# Altium schematic sheet Power.SchDoc
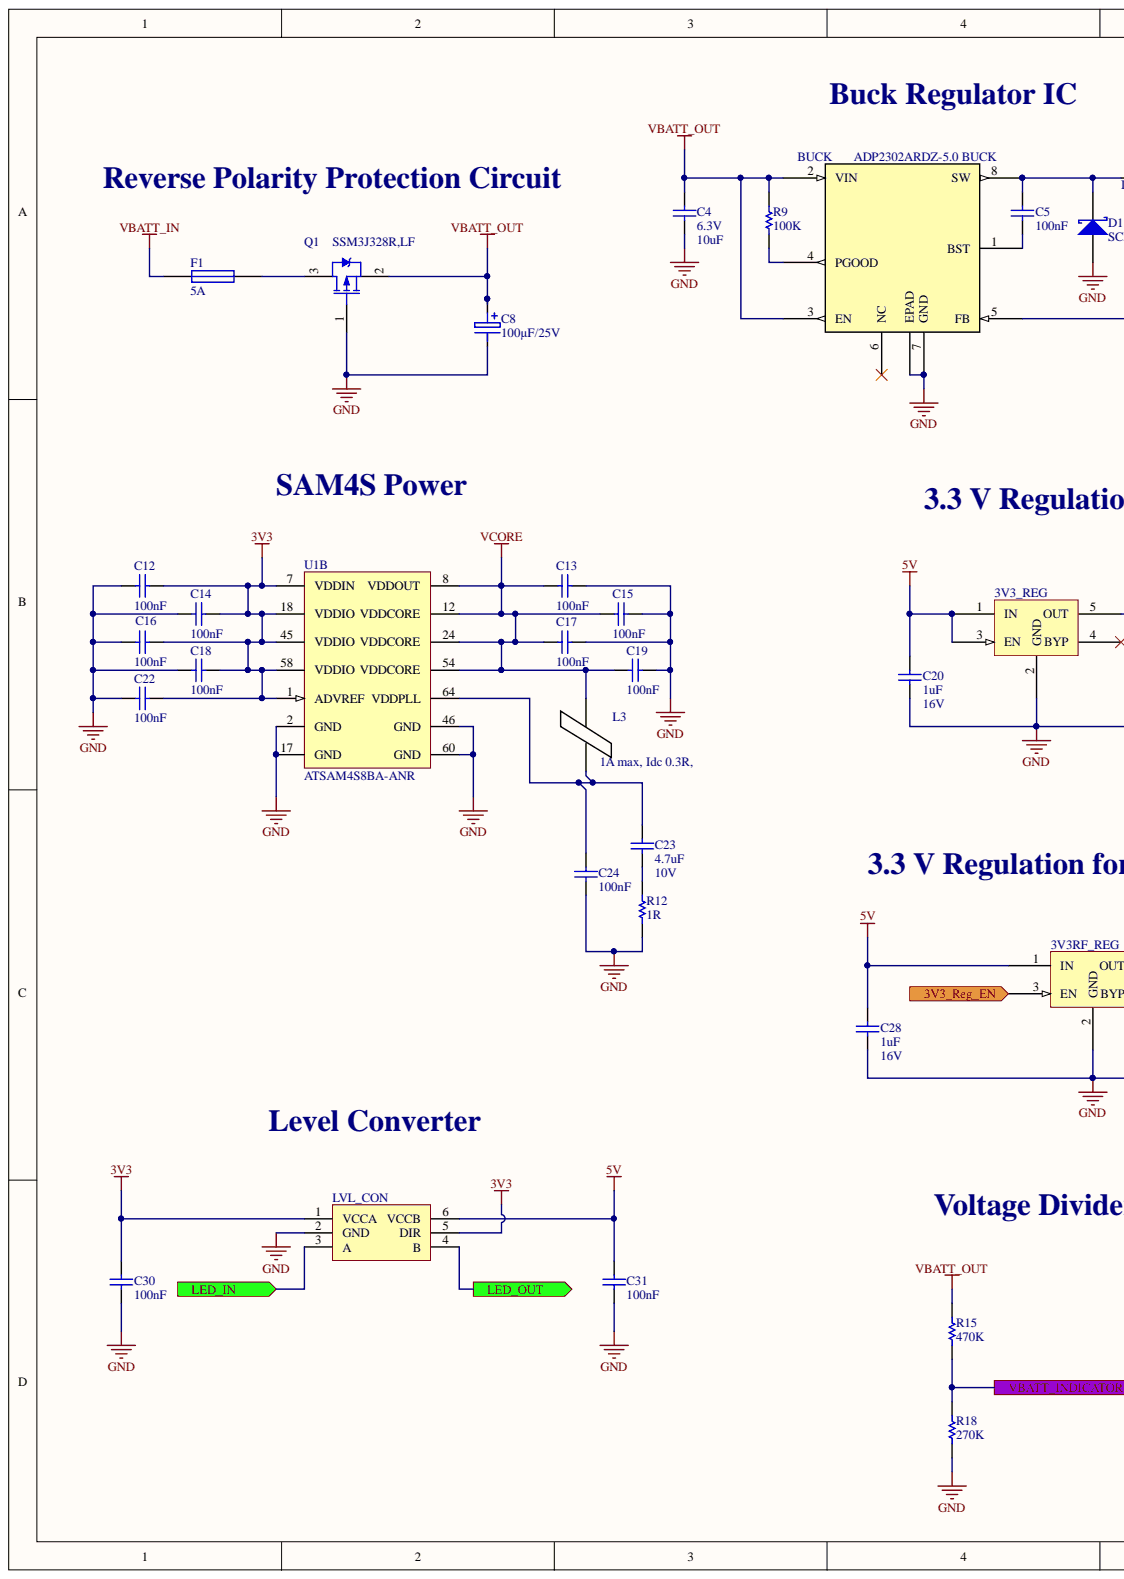
[diagram p0: Power.SchDoc, left]
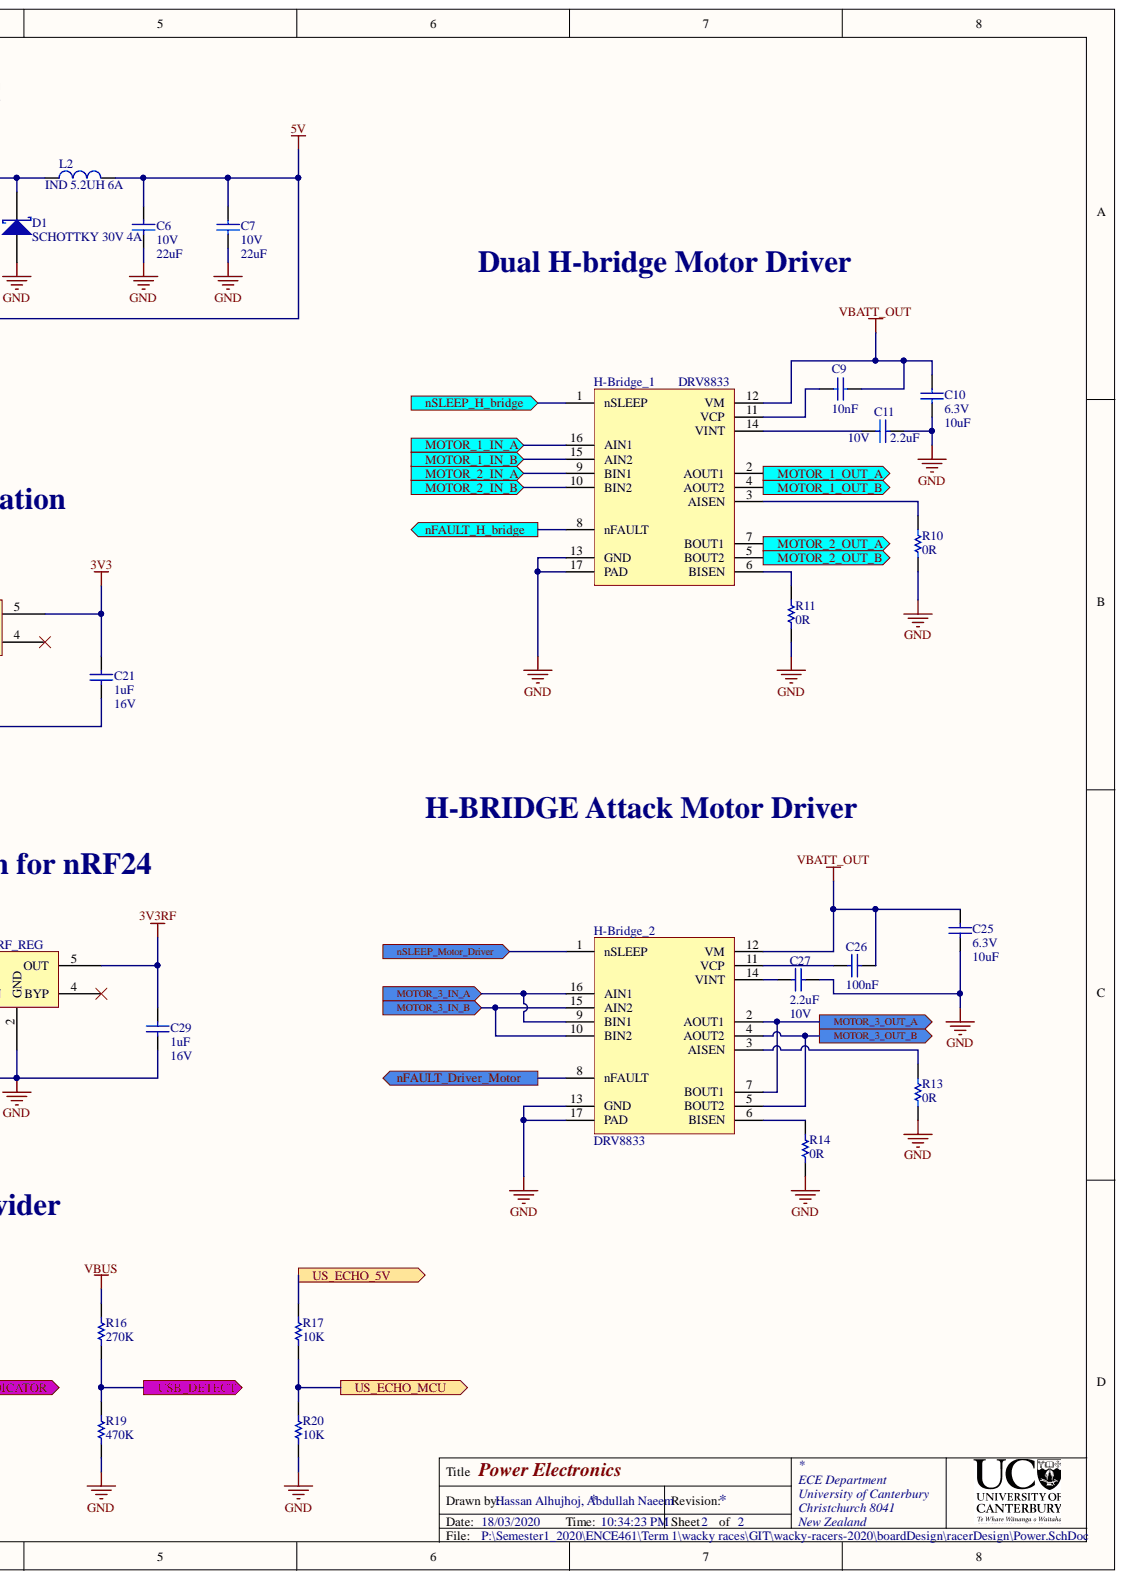
[diagram p1: Power.SchDoc, right]

## Parts
3V3_REG  CAT6219-330TDGT3  [SOT95P280X145-5N]  (value not drawn)
3V3RF_REG  CAT6219-330TDGT3  [SOT95P280X145-5N]  (value not drawn)
BUCK  ADP2302ARDZ-5.0 BUCK  [RD_8_1]
C4  10uF  Voltage - Rated: 6.3V  [CAPC1608L]
C5  100nF  [CAPC1608L]
C6  22uF  Max Voltage: 10V  [1206 (3216 metric)]
C7  22uF  Max Voltage: 10V  [1206 (3216 metric)]
C8  100µF/25V  [CAPAE6666X77M]
C9  10nF  [CAPC1608L]
C10  10uF  Voltage - Rated: 6.3V  [CAPC1608L]
C11  2.2uF  Max Voltage: 10V  [CAPC1608L]
C12  100nF  [CAPC1608L]
C13  100nF  [CAPC1608L]
C14  100nF  [CAPC1608L]
C15  100nF  [CAPC1608L]
C16  100nF  [CAPC1608L]
C17  100nF  [CAPC1608L]
C18  100nF  [CAPC1608L]
C19  100nF  [CAPC1608L]
C20  1uF  Max Voltage: 16V  [CAPC1608L]
C21  1uF  Max Voltage: 16V  [CAPC1608L]
C22  100nF  [CAPC1608L]
C23  4.7uF  Max Voltage: 10V  [CAPC1608N]
C24  100nF  [CAPC1608L]
C25  10uF  Voltage - Rated: 6.3V  [CAPC1608L]
C26  100nF  [CAPC1608L]
C27  2.2uF  Max Voltage: 10V  [CAPC1608L]
C28  1uF  Max Voltage: 16V  [CAPC1608L]
C29  1uF  Max Voltage: 16V  [CAPC1608L]
C30  100nF  [CAPC1608L]
C31  100nF  [CAPC1608L]
D1  SCHOTTKY 30V 4A  [SMC]
F1  5A  [RESC3216N]
H-Bridge_1  DRV8833  [HTSOP16]
H-Bridge_2  DRV8833  [HTSOP16]
L2  IND 5.2UH 6A  [INDUCTOR5u2]
L3  1A max, Idc 0.3R,  [INDC3216L]
LVL_CON  SN74LVC1T45  [SOT23-6_N]  (value not drawn)
Q1  SSM3J328R,LF  [SOT23_L]
R9  100K  [RESC1608L]
R10  0R  [RESC1608L]
R11  0R  [RESC1608L]
R12  1R  [RESC3216L]
R13  0R  [RESC1608L]
R14  0R  [RESC1608L]
R15  470K  [RESC1608L]
R16  270K  [RESC1608L]
R17  10K  [RESC1608L]
R18  270K  [RESC1608L]
R19  470K  [RESC1608L]
R20  10K  [RESC1608L]
U1  ATSAM4S8BA-ANR  [LQFP64_L]  (part B)

## Ports
3V3_Reg_EN, LED_IN, LED_OUT, MOTOR_1_IN_A, MOTOR_1_IN_B, MOTOR_1_OUT_A, MOTOR_1_OUT_B, MOTOR_2_IN_A, MOTOR_2_IN_B, MOTOR_2_OUT_A, MOTOR_2_OUT_B, MOTOR_3_IN_A, MOTOR_3_IN_B, MOTOR_3_OUT_A, MOTOR_3_OUT_B, nFAULT_Driver_Motor, nFAULT_H_bridge, nSLEEP_H_bridge, nSLEEP_Motor_Driver, US_ECHO_5V, US_ECHO_MCU, USB_DETECT, VBATT_INDICATOR

## Nets
3V3: 3V3_REG.5(OUT) C12.2 C14.2 C16.2 C18.2 C21.2 C22.2 C30.2 LVL_CON.1(VCCA) LVL_CON.5(DIR) U1.1(ADVREF) U1.7(VDDIN) U1.18(VDDIO) U1.45(VDDIO) U1.58(VDDIO)
3V3RF: 3V3RF_REG.5(OUT) C29.2
5V: 3V3_REG.1(IN) 3V3_REG.3(EN) 3V3RF_REG.1(IN) BUCK.5(FB) C6.2 C7.2 C20.1 C28.1 C31.2 L2.2 LVL_CON.6(VCCB)
GND: 3V3_REG.2(GND) 3V3RF_REG.2(GND) BUCK.7(GND) BUCK.EPAD C4.1 C6.1 C7.1 C8.1 C10.1 C11.2 C12.1 C13.2 C14.1 C15.2 C16.1 C17.2 C18.1 C19.2 C20.2 C21.1 C22.1 C24.1 C25.1 C27.2 C28.2 C29.1 C30.1 C31.1 D1.1 H-Bridge_1.13(GND) H-Bridge_1.17(PAD) H-Bridge_2.13(GND) H-Bridge_2.17(PAD) LVL_CON.2(GND) Q1.1 R10.2 R11.1 R12.2 R13.2 R14.2 R18.1 R19.1 R20.1 U1.2(GND) U1.17(GND) U1.46(GND) U1.60(GND)
VBATT_IN: F1.1
VBATT_OUT: BUCK.2(VIN) BUCK.3(EN) C4.2 C8.2 C9.2 C10.2 C25.2 C26.2 H-Bridge_1.12(VM) H-Bridge_2.12(VM) Q1.2 R9.2 R15.2
VBUS: R16.2
VCORE: C13.1 C15.1 C17.1 C19.1 L3.2 U1.8(VDDOUT) U1.12(VDDCORE) U1.24(VDDCORE) U1.54(VDDCORE)
3V3_Reg_EN: 3V3RF_REG.3(EN) ; port 3V3_Reg_EN
LED_IN: LVL_CON.3(A) ; port LED_IN
LED_OUT: LVL_CON.4(B) ; port LED_OUT
MOTOR_1_IN_A: H-Bridge_1.16(AIN1) ; port MOTOR_1_IN_A
MOTOR_1_IN_B: H-Bridge_1.15(AIN2) ; port MOTOR_1_IN_B
MOTOR_1_OUT_A: H-Bridge_1.2(AOUT1) ; port MOTOR_1_OUT_A
MOTOR_1_OUT_B: H-Bridge_1.4(AOUT2) ; port MOTOR_1_OUT_B
MOTOR_2_IN_A: H-Bridge_1.9(BIN1) ; port MOTOR_2_IN_A
MOTOR_2_IN_B: H-Bridge_1.10(BIN2) ; port MOTOR_2_IN_B
MOTOR_2_OUT_A: H-Bridge_1.7(BOUT1) ; port MOTOR_2_OUT_A
MOTOR_2_OUT_B: H-Bridge_1.5(BOUT2) ; port MOTOR_2_OUT_B
MOTOR_3_IN_A: H-Bridge_2.9(BIN1) H-Bridge_2.16(AIN1) ; port MOTOR_3_IN_A
MOTOR_3_IN_B: H-Bridge_2.10(BIN2) H-Bridge_2.15(AIN2) ; port MOTOR_3_IN_B
MOTOR_3_OUT_A: H-Bridge_2.2(AOUT1) H-Bridge_2.7(BOUT1) ; port MOTOR_3_OUT_A
MOTOR_3_OUT_B: H-Bridge_2.4(AOUT2) H-Bridge_2.5(BOUT2) ; port MOTOR_3_OUT_B
nFAULT_Driver_Motor: H-Bridge_2.8(nFAULT) ; port nFAULT_Driver_Motor
nFAULT_H_bridge: H-Bridge_1.8(nFAULT) ; port nFAULT_H_bridge
nSLEEP_H_bridge: H-Bridge_1.1(nSLEEP) ; port nSLEEP_H_bridge
nSLEEP_Motor_Driver: H-Bridge_2.1(nSLEEP) ; port nSLEEP_Motor_Driver
US_ECHO_5V: R17.2 ; port US_ECHO_5V
US_ECHO_MCU: R17.1 R20.2 ; port US_ECHO_MCU
USB_DETECT: R16.1 R19.2 ; port USB_DETECT
VBATT_INDICATOR: R15.1 R18.2 ; port VBATT_INDICATOR
NetBUCK_1: BUCK.1(BST) C5.1
NetBUCK_4: BUCK.4(PGOOD) R9.1
NetBUCK_8: BUCK.8(SW) C5.2 D1.2 L2.1
NetC9_1: C9.1 H-Bridge_1.11(VCP)
NetC11_1: C11.1 H-Bridge_1.14(VINT)
NetC23_1: C23.1 R12.1
NetC23_2: C23.2 C24.2 L3.1 U1.64(VDDPLL)
NetC26_1: C26.1 H-Bridge_2.11(VCP)
NetC27_1: C27.1 H-Bridge_2.14(VINT)
NetF1_2: F1.2 Q1.3
NetH-Bridge_1_3: H-Bridge_1.3(AISEN) R10.1
NetH-Bridge_1_6: H-Bridge_1.6(BISEN) R11.2
NetH-Bridge_2_3: H-Bridge_2.3(AISEN) R13.1
NetH-Bridge_2_6: H-Bridge_2.6(BISEN) R14.1

Not connected: 3V3_REG 4(BYP); 3V3RF_REG 4(BYP); BUCK 6(NC)

## Library data of the parts
3V3_REG 3V3RF_REG = SV_CAT6219-330TDGT3: IC REG LIN 3.3V 500MA TSOT23-5; Voltage - Input (Max): 5.5V; Voltage Dropout (Max): 0.5V @ 500mA; Base Part Number: CAT6219; Component Kind: Standard; ComponentLink1Description: http://www.onsemi.com/pub/Collateral/CAT6219-D.PDF; ComponentLink1URL: http://www.onsemi.com/pub/Collateral/CAT6219-D.PDF; Current - Output: 500mA; Library Name: ENCE461AD19_19V2.IntLib; Manufacturer Part Number: CAT6219-330TDGT3; Package / Case: SOT-23-5 Thin, TSOT-23-5; Supplier: Digi-Key; Supplier 1: Digi-Key; Supplier Device Package: TSOT-23-5; Voltage - Output (Min/Fixed): 3.3V
BUCK = ADP2302ARDZ-5.0-R7: IC REG BUCK 5V 2A 8SOIC; Frequency - Switching: 700kHz; Output Type: Fixed; Vendor: Analog Devices Inc; Voltage - Input (Max): 20V; Component Kind: Standard; Current - Output: 2A; Library Name: ENCE461AD19_19V2.IntLib; Manufacturer_Part_Number: ADP2302ARDZ-5.0-R7; Supplier 1: Digi-Key; Supplier Part Number 1: ADP2302ARDZ-5.0-R7CT-ND; Type: DEV; Value: Value; Voltage - Output (Min/Fixed): 5V
C4 C10 C25 = CC_10uF_0603: CAP CER 10UF X5R +-20% 6V3 0603; Component Kind: Standard; Library Name: ECE AD19Components_19V1.IntLib; Signal Integrity: C0805; Simulation: CAP; Storage Location: PCB Assembly WS; Supplier: ECE Dept; Value: 10uF
C5 C12-C19 C22 C24 C26 C30 C31 = CC_100nF_0603: CAP CER 100nF X7R +-10% 50V 0603; Code_IPC: 1608 [0603]; Component Kind: Standard; Library Name: ECE AD19Components_19V1.IntLib; PackageReference: 0603; Signal Integrity: C0603; Simulation: CAP; Storage Location: PCB Assembly WS; Supplier: ECE Dept; Value: 100nF
C6 C7 = CC_22uF_1206: CAP CER 22uF Y5V -20% +80% 10V 1206; Component Kind: Standard; Dielectric: Y5V; Library Name: ECE AD19Components_19V1.IntLib; Simulation: CAP; Storage Location: PCB Assembly WS; Supplier: ECE Dept; Value: 22uF
C8 = CA_100uF_25V: CAP ALUM 100UF 25V 20% SMD; Manufacturer: Nichicon; Manufacturer Part Number: UWT1E101MCL1GS; Ripple Current: 91mA; Capacitance: 100µF/25V; Component Kind: Standard; Height - Seated (Max): 0.303" (7.70mm); Library Name: ECE AD19Components_19V1.IntLib; Mounting Type: Surface Mount; Operating Temperature: -55°C ~ 105°C; Package / Case: Radial, Can - SMD; Size / Dimension: 0.248" Dia (6.30mm); Supplier 1: Digi-Key; Supplier Part Number 1: 493-2190-1-ND
C9 = CC_10nF_0603: CAP CER 10nF X7R +-10% 50V 0603; Code_IPC: 1608 [0603]; Component Kind: Standard; Library Name: ECE AD19Components_19V1.IntLib; PackageReference: 0603; Signal Integrity: C0603; Simulation: CAP; Storage Location: PCB Assembly WS; Supplier: ECE Dept; Value: 10nF
C11 C27 = CC_2.2uF_0603: CAP CER 2.2uF X5R +-20% 10V 0603; Code_IPC: 1608 [0603]; Component Kind: Standard; Library Name: ECE AD19Components_19V1.IntLib; PackageReference: 0603; Signal Integrity: C0603; Simulation: CAP; Storage Location: PCB Assembly WS; Supplier: ECE Dept; Value: 2.2uF
C20 C21 C28 C29 = CC_1uF_0603: CAP CER 1uF Y5V -20% +80% 16V 0603; Code_IPC: 1608 [0603]; Component Kind: Standard; Library Name: ECE AD19Components_19V1.IntLib; PackageReference: 0603; Signal Integrity: C0603; Simulation: CAP; Storage Location: PCB Assembly WS; Supplier: ECE Dept; Value: 1uF
C23 = CC_4.7uF_0603: CAP CER 4.7uF X5R +-20%  10V 0603; Note: Footprint corresponds to dimensions for bulk cassette packaging. This case size has tightened tolerances.; Code_IPC: 2012[0805]; Component Kind: Standard; Library Name: ECE AD19Components_19V1.IntLib; PackageReference: 0805; Simulation: CAP; Storage Location: PCB Assembly WS; Supplier: ECE Dept; Value: 4.7uF
D1 = MBRS330T3G: DIODE SCHOTTKY 30V 4A SMC; Manufacturer: ON Semiconductor; Speed: Fast Recovery =< 500ns, > 200mA (Io); Base Part Number: MBRS330; Capacitance @ Vr, F: -; Category: Discrete Semiconductor Products; Component Kind: Standard; ComponentLink1Description: http://www.onsemi.com/pub/Collateral/MBRS340T3-D.PDF; ComponentLink1URL: http://www.onsemi.com/pub/Collateral/MBRS340T3-D.PDF; Current - Average Rectified (Io): 4A; Diode Type: Schottky; Library Name: ENCE461AD19_19V2.IntLib; Manufacturer Part Number: MBRS330T3G; Mounting Type: Surface Mount; Operating Temperature - Junction: -65°C ~ 150°C; Package / Case: DO-214AB, SMC; Packaging: Cut Tape (CT); Part Status: Active; Pricing 1: 1=0.93, 10=0.79, 100=0.5902, 500=0.46368, 1000=0.35829 (NZD); RoHS: RoHS Compliant; Stock 1: 8919; Supplier 1: Digi-Key; Supplier Device Package: SMC; Supplier Part Number 1: MBRS330T3GOSCT-ND
F1 = FS_Fuse_5.0A: Fuse 5.0A Fast Acting 1206 SMD; Manufacturer: Bel TRP; Manufacturer Part Number: C1F5; Approvals: CE,cURus; DC Cold Resistance: 19mR; Fuse Type: Board Mount (Cartridge Style Excluded); Voltage Rating: 125V; Voltage Rating (DC): 63V; Case/Package: 1206; Case Code (Imperial): 1206; Case Code (Metric): 3216; Component Kind: Standard; ComponentLink1Description: http://www.farnell.com/datasheets/2571625.pdf; ComponentLink1URL: http://www.farnell.com/datasheets/2571625.pdf; ComponentLink2Description: http://belfuse.com/pdfs/C1F.pdf; ComponentLink2URL: http://belfuse.com/pdfs/C1F.pdf; ComponentLink3Description: http://www.mouser.com/ds/2/643/C1F-594910.pdf; ComponentLink3URL: http://www.mouser.com/ds/2/643/C1F-594910.pdf; ComponentLink4Description: https://belfuse.com/resources/StewartConnector/C1F.pdf; ComponentLink4URL: https://belfuse.com/resources/StewartConnector/C1F.pdf; Contact Plating: Nickel,Tin; Current Rating: 5A; Length: 0.126inch; Library Name: ECE AD19Components_19V1.IntLib; Max Operating Temperature: 125°C; Min Operating Temperature: -55°C; Mount: Surface Mount; Number of Pins: 2; Packaging: Tape and Reel; Pricing 1: 1=0.32, 5=0.312, 10=0.298, 50=0.2662, 100=0.2479, 250=0.22032, 500=0.1836, 1000=0.17442 (USD); Stock 1: 725; Supplier 1: Digi-Key; Supplier 2: Element14; Supplier Part Number 1: 507-1886-1-ND; Supplier Part Number 2: 2848595; Value: 5A; Voltage Rating (AC): 125V; Width: 0.062inch
H-Bridge_1 H-Bridge_2 = DRV8833: Dual H-Bridge Motor Driver 1.5A; Component Kind: Standard; Library Name: ENCE461AD19_19V2.IntLib
L2 = CDRH104RNP-5R2NC: FIXED IND 5.2UH 6A 22 MOHM SMD; Manufacturer: Sumida America Components Inc.; Current - Saturation: 5.6A; DC Resistance (DCR): 22 mOhm Max; Material - Core: Ferrite; Shielding: Shielded; Tolerance: ±30%; Category: Inductors, Coils, Chokes; Component Kind: Standard; ComponentLink1Description: http://products.sumida.com/products/pdf/CDRH104R.pdf; ComponentLink1URL: http://products.sumida.com/products/pdf/CDRH104R.pdf; Frequency - Self Resonant: -; Height - Seated (Max): 0.157" (4.00mm); Library Name: ENCE461AD19_19V2.IntLib; Manufacturer Part Number: CDRH104RNP-5R2NC; Mounting Type: Surface Mount; Operating Temperature: -40°C ~ 100°C; Package / Case: Nonstandard; Packaging: Cut Tape (CT); Part Status: Active; Pricing 1: 1=2.9, 10=2.678, 100=1.8743, 500=1.5173 (NZD); Q @ Freq: -; Ratings: -; RoHS: RoHS Compliant; Size / Dimension: 0.402" L x 0.394" W (10.20mm x 10.00mm); Stock 1: 3849; Supplier 1: Digi-Key; Supplier Device Package: -; Supplier Part Number 1: 308-2280-1-ND; Type: -
L3 = IN_Ferrite-Bead: Ferrite Bead, Rdc 0.3R, Z100MHZ 600R, Imax 1A, SMD 1206; Manufacturer: FAIR-RITE; Manufacturer Part Number: 2512066017Y1; DC Current Rating: 1; DC Resistance Max: 0.08; Ferrite Case Style: 1206 ^3216 Metric]; Frequency Range: 50MHz to 1; Impedance: 600; Impedance Tolerance: ± 25%; Component Kind: Standard; Ferrite Mounting: SMD; Library Name: ECE AD19Components_19V1.IntLib; Manufacturer PN: 2512066017Y1; Signal Integrity: Inductor Isolated; Storage Location: PCB Assembly WS; Supplier: ECE Dept; Supplier 1: Farnell; Supplier Part Number 1: 1612060; Value: 1A max, Idc 0.3R,
LVL_CON = SN74LVC1T45: 1.8V - 5V level converter; Component Kind: Standard; ComponentLink1Description: Single-Bit Dual-Supply Bus Transceiver with Configurable Voltage-Level Shifting and 3-State Outputs; ComponentLink1URL: http://www.ti.com/lit/ds/symlink/sn74lvc1t45.pdf; Library Name: ENCE461_extras_2020.IntLib
Q1 = TM_SSM3J328R: MOSFET P-CH 20V 6A SOT23-3; Manufacturer: Toshiba; Drain to Source Breakdown Voltage: -20V; Drain to Source Resistance: 47.4mR; Element Configuration: Single; FET Feature: Logic Level Gate, 1.5V Drive; FET Type: MOSFET P-Channel, Metal Oxide; Gate Charge (Qg) @ Vgs: 12.8nC @ 4.5V; Gate to Source Voltage (Vgs): 8V; Input Capacitance: 840pF; Input Capacitance (Ciss) @ Vds: 840pF @ 10V; Max Power Dissipation: 1W; Number of Channels: 1; Power Dissipation: 2W; Rds On Max: 29.8mR; Turn-Off Delay Time: 107ns; Turn-On Delay Time: 32ns; Vgs(th) (Max) @ Id: 1V @ 1mA; Case/Package: SOT-23-3; Component Kind: Standard; ComponentLink1Description: https://datasheet.ciiva.com/11158/ssm3j328r-datasheet-en-20140301-11158652.pdf; ComponentLink1URL: https://datasheet.ciiva.com/11158/ssm3j328r-datasheet-en-20140301-11158652.pdf; Continuous Drain Current (ID): 6A; Current - Continuous Drain (Id) @ 25° C: 6A; Drain to Source Voltage (Vdss): 20V; Library Name: ECE AD19Components_19V1.IntLib; Manufacturer Part Number: SSM3J328R,LF; Max Operating Temperature: 150°C; Min Operating Temperature: -55°C; Mount: Surface Mount; Number of Elements: 1; Number of Pins: 3; Package / Case: SOT-23-3 Flat Leads; Package Quantity: 3000; Packaging: Tape and Reel; Power - Max: 1W; Pricing: 1=0.79, 10=0.658, 25=0.5772, 100=0.4939, 250=0.42836, 500=0.36284, 1000=0.27972 (NZD); Radiation Hardening: No; Storage Location: PCB Assembly WS; Supplier: Digi-Key; Supplier 1: Digi-Key; Supplier Device Package: SOT-23F; Supplier Part Number: SSM3J328RLFDKR-ND; Supplier Part Number 1: SSM3J328RLFDKR-ND
R9 = RS_100K: RES 100K OHM 1/10W 5% 0603 SMD; Component Kind: Standard; Library Name: ECE AD19Components_19V1.IntLib; Signal Integrity: Res; Simulation: RESISTOR; Storage Location: PCB Assembly WS; Supplier: ECE Dept; Value: 100K
R10 R11 R13 R14 = RS_0R0: RES 0.0 OHM 1/10W 5% 0603 SMD; Component Kind: Standard; Library Name: ECE AD19Components_19V1.IntLib; Signal Integrity: Res; Simulation: RESISTOR; Storage Location: PCB Assembly WS; Supplier: ECE Dept; Value: 0R
R12 = RT_1R: RES 1R OHM 1/4W 5% 1206 SMD; Component Kind: Standard; Library Name: ECE AD19Components_19V1.IntLib; Storage Location: PCB Assembly WS; Supplier: ECE Dept; Value: 1R
R15 R16 = RS_27R: RES 27 OHM 1/10W 5% 0603 SMD; Component Kind: Standard; Library Name: ECE AD19Components_19V1.IntLib; Signal Integrity: Res; Simulation: RESISTOR; Storage Location: PCB Assembly WS; Supplier: ECE Dept
  R15: Value: 470K
  R16: Value: 270K
R17 R20 = RS_10K: RES 10.0K OHM 1/10W 5% 0603 SMD; Component Kind: Standard; Library Name: ECE AD19Components_19V1.IntLib; Signal Integrity: Res; Simulation: RESISTOR; Storage Location: PCB Assembly WS; Supplier: ECE Dept; Value: 10K
R18 R19 = RS_47R: RES 47 OHM 1/10W 5% 0603 SMD; Component Kind: Standard; Library Name: ECE AD19Components_19V1.IntLib; Signal Integrity: Res; Simulation: RESISTOR; Storage Location: PCB Assembly WS; Supplier: ECE Dept
  R18: Value: 270K
  R19: Value: 470K
U1 = SE_ATSAM4S16BA-MU: IC MCU 32BIT 512KB FLASH 64LQFP; Manufacturer: Atmel; Connectivity: I²C, IrDA, Memory Card, SPI, SSC, UART/USART, USB; Core Processor: ARM® Cortex®-M4; Core Size: 32-Bit; Data Converters: A/D 11x12b, D/A 2x12b; Number of I/O: 47; Peripherals: Brown-out Detect/Reset, DMA, POR, PWM, WDT; Program Memory Size: 512KB (512K x 8); RAM Size: 128K x 8; Speed: 120MHz; Voltage - Supply (Vcc/Vdd): 1.62 V ~ 3.6 V; Code_JEDEC: MO-220VMMD-3; Component Kind: Standard; ComponentLink1Description: Manufacturer URL; ComponentLink1URL: http://www.atmel.com/; ComponentLink2Description: SAM4S Series Datasheet; ComponentLink2URL: http://www.atmel.com/dyn/resources/prod_documents/11100.pdf; ComponentLink3Description: SAM4S Series Summary; ComponentLink3URL: http://www.atmel.com/dyn/resources/prod_documents/11100S.pdf; Library Name: ECE AD19Components_19V1.IntLib; Manufacturer Part Number: ATSAM4S8BA-ANR; Package / Case: 64-LQFP; PackageDescription: 64-Pin Quad Flat No-Lead (QFN) Sawn, 9 x 9 x 1.0 mm Body, 0.5 mm Pitch, Exposed Pad; PackageReference: 64PS; PackageVersion: revJ, Jul-2007; Packaging: Cut Tape (CT); Program Memory Type: FLASH; Supplier 1: Digi-Key; Supplier Part Number 1: ATSAM4S8BA-ANRCT-ND
